# Altium schematic sheet Main board.SchDoc("Main board")
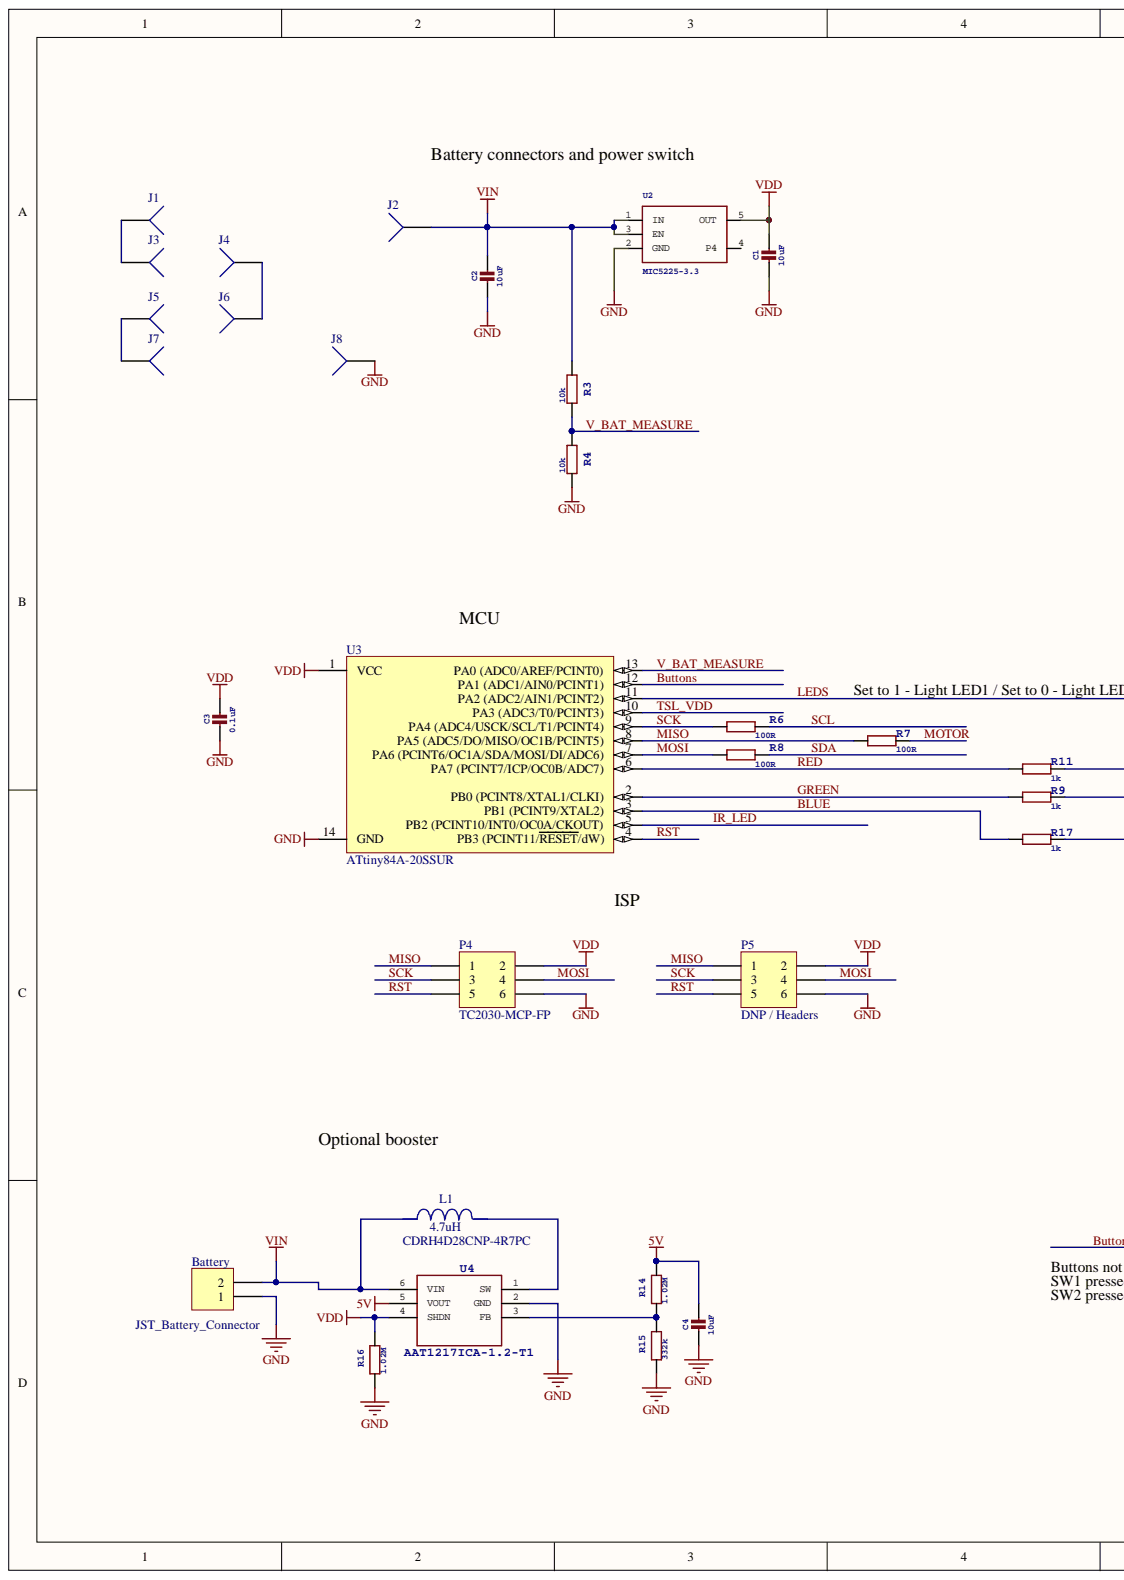
[diagram p0: Main board.SchDoc, left]
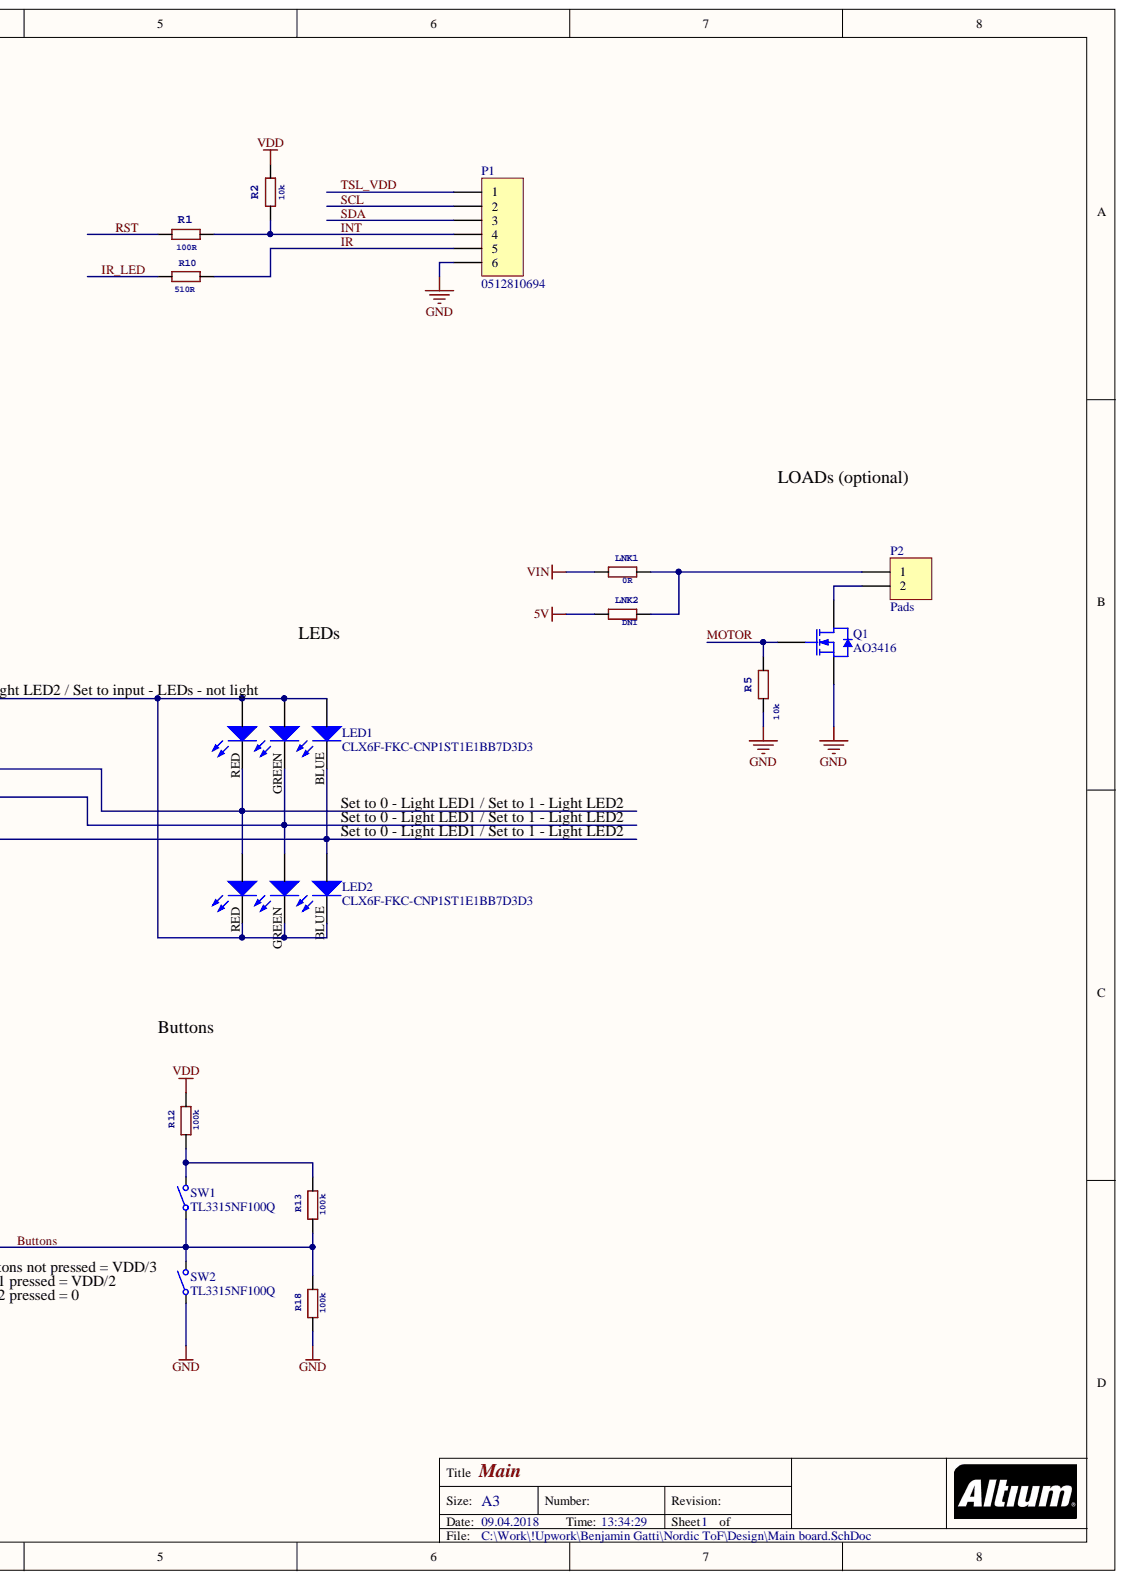
[diagram p1: Main board.SchDoc, right]

## Parts
Battery  JST_Battery_Connector  [S2B-PH-K-S(LF)(SN)]
C1  10uF  [CAPC1608X100N]
C2  10uF  [CAPC1608X100N]
C3  0.1uF  [CAPC1608X100N]
C4  10uF  [CAPC1608X100N]
J1  Battery  [Battery]  (value not drawn)
J2  Battery  [Battery]  (value not drawn)
J3  Battery  [Battery]  (value not drawn)
J4  Battery  [Battery]  (value not drawn)
J5  Battery  [Battery]  (value not drawn)
J6  Battery  [Battery]  (value not drawn)
J7  Battery  [Battery]  (value not drawn)
J8  Battery  [Battery]  (value not drawn)
L1  CDRH4D28CNP-4R7PC  value 4.7uH  [CDRH4D28]
LED1  CLX6F-FKC-CNP1ST1E1BB7D3D3  [6-PLCC]
LED2  CLX6F-FKC-CNP1ST1E1BB7D3D3  [6-PLCC]
LNK1  0R  [RESC1608X50N]
LNK2  DNI  [RESC1608X50N]
P1  0512810694  [0512810694]
P2  Pads  [HDR1X2]
P4  TC2030-MCP-FP  [TC2030-MCP-FP]
P5  DNP / Headers  [HDR2X3]
Q1  AO3416  [SOT95P240X110-3N]
R1  100R  [RESC1608X50N]
R2  10k  [RESC1608X50N]
R3  10k  [RESC1608X50N]
R4  10k  [RESC1608X50N]
R5  10k  [RESC1608X50N]
R6  100R  [RESC1608X50N]
R7  100R  [RESC1608X50N]
R8  100R  [RESC1608X50N]
R9  1k  [RESC1608X50N]
R10  510R  [RESC1608X50N]
R11  1k  [RESC1608X50N]
R12  100k  [RESC1608X50N]
R13  100k  [RESC1608X50N]
R14  1.02M  [RESC1608X50N]
R15  332k  [RESC1608X50N]
R16  1.02M  [RESC1608X50N]
R17  1k  [RESC1608X50N]
R18  100k  [RESC1608X50N]
SW1  TL3315NF100Q  [TL3315NF100Q]
SW2  TL3315NF100Q  [TL3315NF100Q]
U2  MIC5225-3.3  [SOT95P280X100-5N]
U3  ATtiny84A-20SSUR  [14S1_N]
U4  AAT1217ICA-1.2-T1  [SOT95P280X100-6N]

## Nets
5V: C4.1 LNK2.1 R14.2 U4.5(VOUT)
GND: Battery.1 C1.2 C2.2 C3.2 C4.2 J8.1 P1.6 P4.6 P5.6 Q1.2 R4.1 R5.1 R15.1 R16.1 R18.1 SW2.1 U2.2(GND) U3.14(GND) U4.2(GND)
VDD: C1.1 C3.1 P4.2 P5.2 R2.2 R12.2 R16.2 U2.5(OUT) U3.1(VCC) U4.4(SHDN)
VIN: Battery.2 C2.1 J2.1 L1.1 LNK1.1 R3.2 U2.1(IN) U2.3(EN) U4.6(VIN)
BLUE: R17.1 U3.3(PB1_(PCINT9/XTAL2))
Buttons: R13.1 R18.2 SW1.1 SW2.2 U3.12(PA1_(ADC1/AIN0/PCINT1))
GREEN: R9.1 U3.2(PB0_(PCINT8/XTAL1/CLKI))
INT: P1.4 R1.2 R2.1
IR: P1.5 R10.2
IR_LED: R10.1 U3.5(PB2_(PCINT10/INT0/OC0A/CKOUT))
LEDS: LED1.BLUE_ANODE LED1.GREEN_ANODE LED1.RED_ANODE LED2.BLUE LED2.GREEN LED2.RED U3.11(PA2_(ADC2/AIN1/PCINT2))
MISO: P4.1 P5.1 R7.1 U3.8(PA5_(ADC5/DO/MISO/OC1B/PCINT5))
MOSI: P4.4 P5.4 R8.1 U3.7(PA6_(PCINT6/OC1A/SDA/MOSI/DI/ADC6))
MOTOR: Q1.1 R5.2 R7.2
RED: R11.1 U3.6(PA7_(PCINT7/ICP/OC0B/ADC7))
RST: P4.5 P5.5 R1.1 U3.4
SCK: P4.3 P5.3 R6.1 U3.9(PA4_(ADC4/USCK/SCL/T1/PCINT4))
SCL: P1.2 R6.2
SDA: P1.3 R8.2
TSL_VDD: P1.1 U3.10(PA3_(ADC3/T0/PCINT3))
V_BAT_MEASURE: R3.1 R4.2 U3.13
NetJ1_1: J1.1 J3.1
NetJ4_1: J4.1 J6.1
NetJ5_1: J5.1 J7.1
NetL1_2: L1.2 U4.1(SW)
NetLED1_BLUE: LED1.BLUE LED2.BLUE_ANODE R17.2
NetLED1_GREEN: LED1.GREEN LED2.GREEN_ANODE R9.2
NetLED1_RED: LED1.RED LED2.RED_ANODE R11.2
NetLNK1_2: LNK1.2 LNK2.2 P2.1
NetP2_2: P2.2 Q1.3
NetR12_1: R12.1 R13.2 SW1.2
NetR14_1: R14.1 R15.2 U4.3(FB)

Not connected: U2 4(P4)

## Library data of the parts
Battery P2 = Header 2: Header, 2-Pin; Signal Integrity: Connector; Library Name: Miscellaneous Connectors.IntLib; LatestRevisionNote: Re-released for DXP Platform.; LatestRevisionDate: 17-Jul-2002; Component Kind: Standard; Publisher: Altium Limited
C1-C4 = CAP_CERAMIC0805-NOOUTLINE: Ceramic Capacitors   For new designs, use the packages preceded by an '_' character since they are more reliable:   The following footprints should be used on most boards: _0402 - Standard footprint for regular board layouts  _0603 - Standard footprint for regular board layouts  _0805 - Standard footprint for regular board layouts  _1206 - Standard footprint for regular board layouts       For extremely tight-pitch boards where space is at a premium, the following 'micro-pitch' footprints can be used (smaller pads, no silkscreen outline, etc.): _0402MP - Micro-pitch footprint for very dense/compact boards  _0603MP - Micro-pitch footprint for very dense/compact boards  _0805MP - Micro-pitch footprint for very dense/compact boards  _1206MP - Micro-pitch footprint for very dense/compact boards; Library Name: microbuilder.IntLib; Component Kind: Standard
J1-J8 = Socket: Signal Integrity: Connector; Library Name: Miscellaneous Connectors.IntLib; LatestRevisionNote: Re-released for DXP Platform.; LatestRevisionDate: 17-Jul-2002; Description: Socket; Component Kind: Standard; Publisher: Altium Limited
L1 = PFL3215-102MEB: Unknown; Manufacturer Part Number: TYS50406R8M-10; PackageReference: TYS50406R8M-10; Library Name: TI WEBENCH; Component Kind: Standard
LNK1 LNK2 R10 R12-R16 R18 = R-EU_R0402: RESISTOR, European symbol; Manufacturer Part Number: RC0402FR-0710KL; Library Name: rcl.IntLib; Component Kind: Standard
P1 = Header 6: Header, 6-Pin; Signal Integrity: Connector; Library Name: Miscellaneous Connectors.IntLib; LatestRevisionNote: Re-released for DXP Platform.; LatestRevisionDate: 17-Jul-2002; Component Kind: Standard; Publisher: Altium Limited
P4 P5 = Header 3X2: Header, 3-Pin, Dual row; Signal Integrity: Connector; Library Name: Miscellaneous Connectors.IntLib; LatestRevisionNote: Re-released for DXP Platform.; LatestRevisionDate: 17-Jul-2002; Component Kind: Standard; Publisher: Altium Limited
Q1 = Transistor N-channel: Library Name: Transistors.SchLib; Description: Transistor N-channel; Component Kind: Standard
R1-R9 R11 R17 = R-EU_R0402: RESISTOR, European symbol; Manufacturer part number: RC0402FR-0710KL; Library Name: rcl.IntLib; Component Kind: Standard
SW1 SW2 = SW-SPST: Manufacturer Part Number: B3U-1000P; Value: Button; Digikey Part Number: SW1256CT-ND; Component Kind: Standard
U2 = VREG_SOT23-5: SOT23-5 Fixed Voltage Regulators      Part	Current Out	V Out	V In	V Dropout	ОёJA (В°C/W)	TJ (В°C)	Digikey Part No.	     ADP121	  150mA	  3.3V	  3.4-5.5V	  0.09V @ 150mA	  --	  --	  ADP121-AUJZ33R7CT-ND	     ADP121	  150mA	  3.0V	  3.1-5.5V	  0.09V @ 150mA	  --	  --	  ADP121-AUJZ30R7CT-ND	     ADP122	  300mA	  3.3V	  3.4-5.5V	  0.085V @ 300mA	  --	  --	  ADP122AUJZ-3.3-R7CT-ND	     ADP1712	  300mA	  3.3V	  3.5-5.5V	  0.17V @ 300mA	  --	  --	  ADP1712AUJZ-3.3-R7TR-ND	     AP7311 (Low Cost)	  150mA	  3.3V	  3.5-6V	  0.15V @ 150mA	  --	  --	  AP7311-33WG-7DICT-ND	     LD39015M18R	  150mA	  1.8V	  1.9V-5.5V	  0.08V @ 100mA	  --	  --	  497-6977-1-ND	     LP2985A-33DBVR	  150mA	  3.3V	  3.3-16V	  0.28V @ 150mA	  206	  150	  296-18479-1-ND	     MCP1824T-3302E/OT	  300mA	  3.3V	  3.5V-6V	  0.2V @ 300mA	  --	  --	  MCP1824T-3302E/OTCT-ND	     MIC5205-2.5YM5 TR	  150mA	  2.5V	  2.7-16V	  0.165V @ 150mA	  --	  --	  576-1257-2-ND	     MIC5205-3.0YM5 TR	  150mA	  3.0V	  3.2V-16V	  0.165V @ 150mA	  --	  --	  576-1258-2-ND	     MIC5205-3.3YM5 TR	  150mA	  3.3V	  3.5V-16V	  0.165V @ 150mA	  --	  --	  576-1259-2-ND	     TPS780330220	  150mA	  3.3V+2.2V	  3.6-5.5V	  250mV Max	  --	  --	  296-23332-1-ND	     TDA3663/N1,135	  100mA	  3.3V	  3.5-45V	  0.18V @ 50mA	  --	  --	  568-5343-1-ND	     	  	  	  	  	  	  	  	     	  	  	  	  	  	  	  	   Max operating temp can be calculated using ОёJA, TJ (max junction temperature), and power in watts.  Set the "Maximum Ambient Temperature" until it reaches TJ ("Max Junction Temperature"), which is the absolute limit for safe use of the regulator: Heat Sink Temperature Calculator   For example, With 12V source into a 3.3V LP2985 and a 30mA load, we are dissipating (12V-3.3V) * 0.03A = 0.261W.  With a ОёJA of 206 В°C/W, a TJ of 150В°C, and 261mW we can safely use the chip without a heat sink up to 75В°C (=147.1В°C Junction Temperature).; Library Name: microbuilder.IntLib; Component Kind: Standard
U3 = ATtiny84-20SSUR: 8-bit AVR Microcontroller, 20MHz, 2.7-5.5V, 8KB Flash, 512 Bytes EEPROM, 512 Bytes SRAM, 14-pin SOIC, Industrial Grade (-40°C to 85°C), Pb-Free, Reel; PackageVersion: revA, Feb-2002; PackageReference: 14S1; PackageDescription: 14-Lead, 0.150” Wide Body, Plastic Gull Wing Small Outline Package (SOIC); Library Name: Atmel Microcontroller tinyAVR.IntLib; DatasheetVersion: revK, Oct-2010; ComponentLink3URL: http://www.atmel.com/dyn/resources/prod_documents/8006S.pdf; ComponentLink3Description: ATtiny24/44/84 Summary; ComponentLink2URL: http://www.atmel.com/dyn/resources/prod_documents/doc8006.pdf; ComponentLink2Description: ATtiny24/44/84 Datasheet; ComponentLink1URL: http://www.atmel.com/; ComponentLink1Description: Manufacturer URL; Component Kind: Standard; Code_JEDEC: MS-012-AB; Code_IPC: SOIC127P600-14
U4 = PAM2423AECADJR: Boost Switching Regulator IC Positive Adjustable 2.7V 1 Output 5.5A (Switch) 8-SOIC (0.154", 3.90mm Width) Exposed Pad; Component Kind: Standard; Library Name: Heater.SCHLIB
LED1 LED2 = CLX6F-FKC-CNP1ST1E1BB7D3D3: Component Kind: Standard; Library Name: BOP IOT.SCHLIB
